# Altium schematic sheet Power.SchDoc(Power)
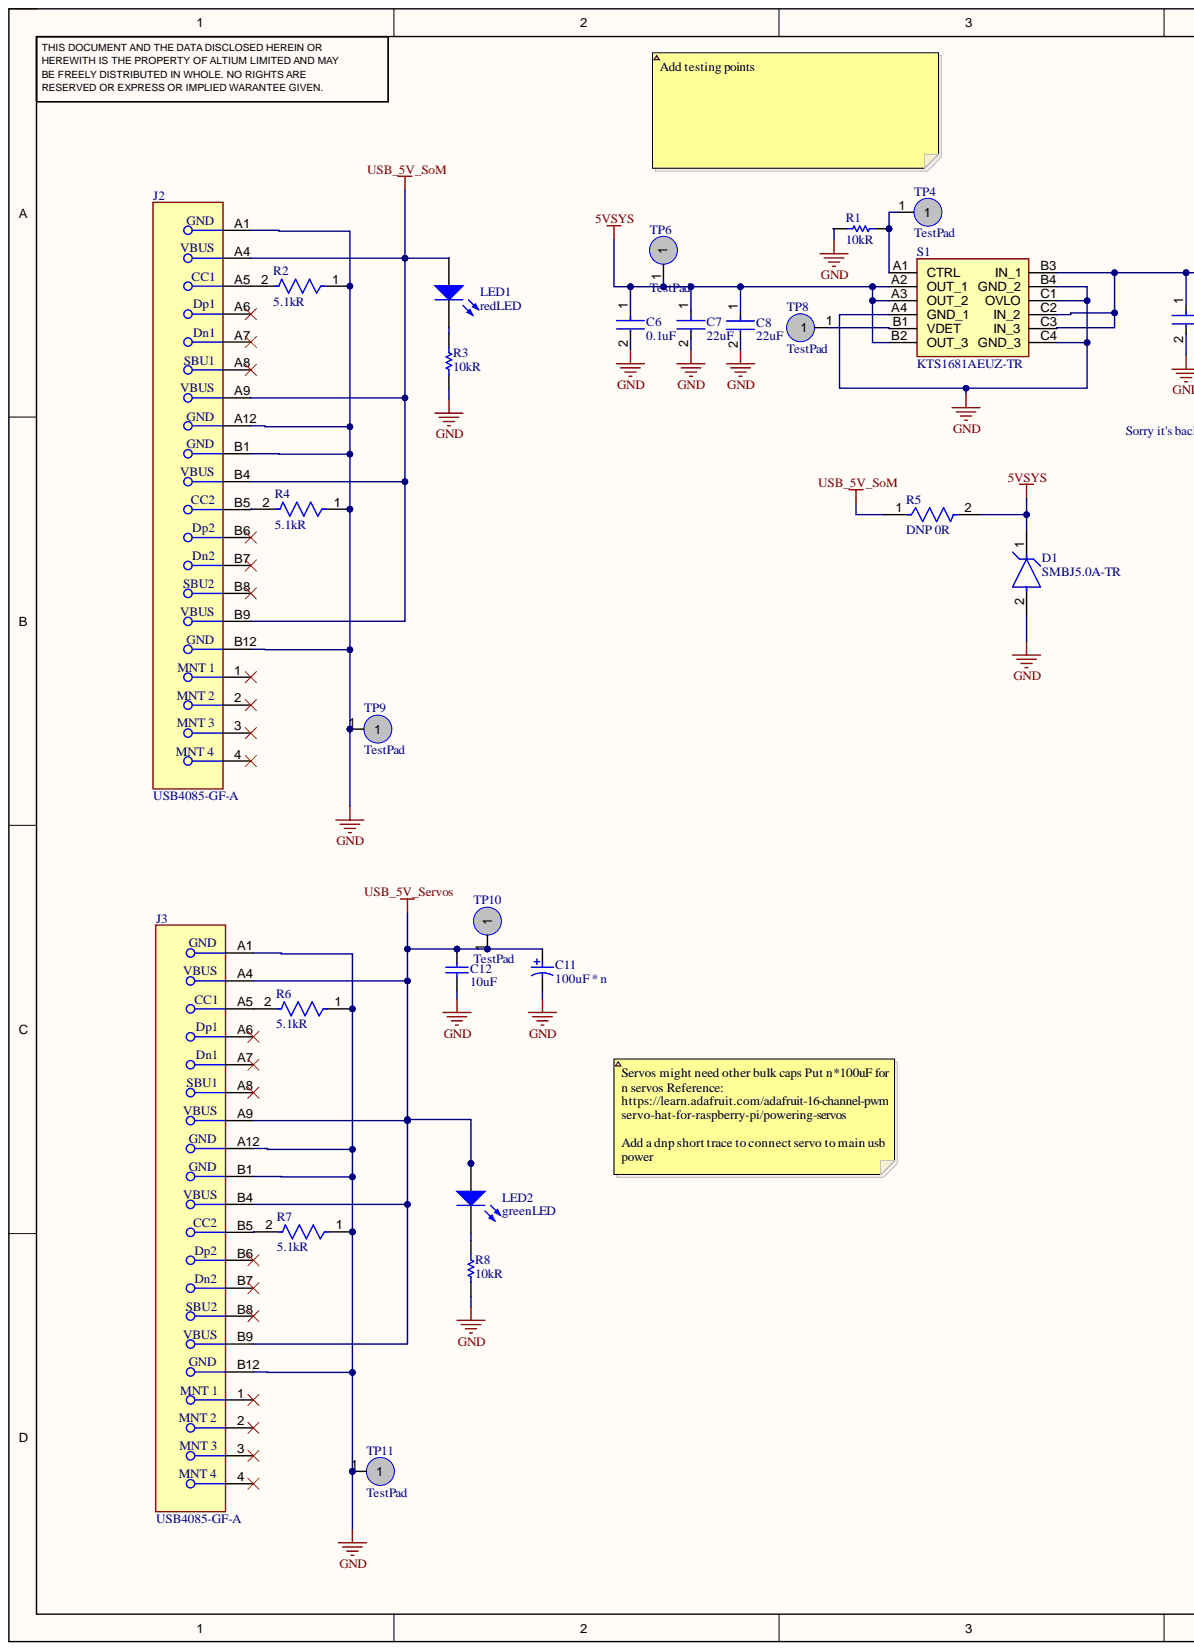
[diagram p0: Power.SchDoc, left]
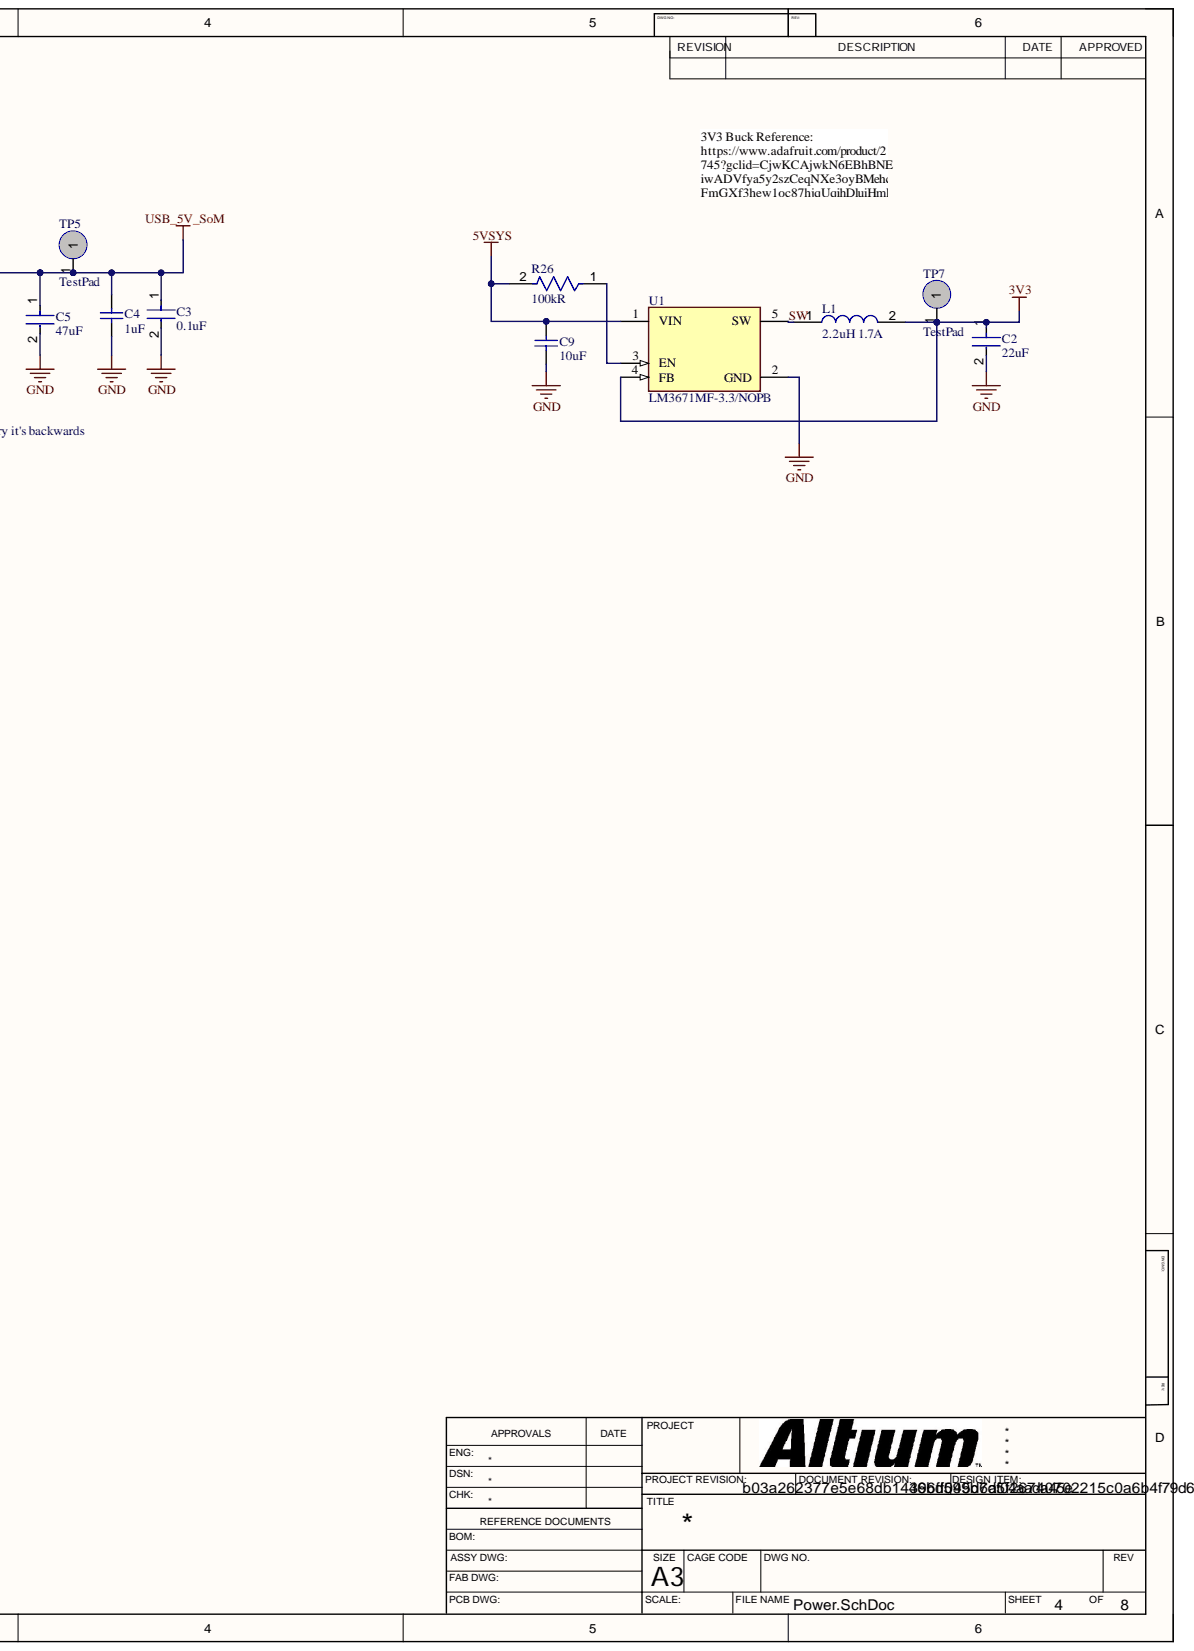
[diagram p1: Power.SchDoc, right]

## Parts
C2  22uF  [FP-GRM21B-0_15-MFG]
C3  0.1uF  [FP-C0603-CJ-MFG]
C4  1uF  [CAPC2013X145X50LL20T25]
C5  47uF  [FP-GRM31C-0_2-e0_3_0_8-IPC_C]
C6  0.1uF  [FP-C0603-CJ-MFG]
C7  22uF  [FP-GRM21B-0_15-MFG]
C8  22uF  [FP-GRM21B-0_15-MFG]
C9  10uF  [CAPC2013X145X20ML20]
C11  100uF * n  [CAPPR65-500-2000X1250X2200]
C12  10uF  [CAPC2013X145X20ML20]
D1  SMBJ5.0A-TR  [FP-SMB-MFG]
J2  USB4085-GF-A  [FP-USB4085-GF-A-MFG]
J3  USB4085-GF-A  [FP-USB4085-GF-A-MFG]
L1  2.2uH 1.7A  [NRH2412T2R2MNGH]
LED1  redLED  [0805_A]
LED2  greenLED  [0805_A]
R1  10kR  [RESC2013X60X35NL10T20]
R2  5.1kR  [FP-CRCW0805-e3-MFG]
R3  10kR  [RESC2013X60X35ML10T20]
R4  5.1kR  [FP-CRCW0805-e3-MFG]
R5  DNP 0R  [FP-RC1206-MFG]
R6  5.1kR  [FP-CRCW0805-e3-MFG]
R7  5.1kR  [FP-CRCW0805-e3-MFG]
R8  10kR  [RESC2013X60X35ML10T20]
R26  100kR  [FP-RC0603-0_55-MFG]
S1  KTS1681AEUZ-TR  [KTS1681AEUZTR]
TP4  TestPad  [TestPad50mil]
TP5  TestPad  [TestPad50mil]
TP6  TestPad  [TestPad50mil]
TP7  TestPad  [TestPad50mil]
TP8  TestPad  [TestPad50mil]
TP9  TestPad  [TestPad50mil]
TP10  TestPad  [TestPad50mil]
TP11  TestPad  [TestPad50mil]
U1  LM3671MF-3.3/NOPB  [FP-DBV0005A-IPC_C]

## Nets
3V3: C2.1 L1.2 TP7.1 U1.4(FB)
5VSYS: C6.1 C7.1 C8.1 C9.2 D1.1 R5.2 R26.2 S1.A2(OUT_1) S1.A3(OUT_2) S1.B2(OUT_3) TP6.1 U1.1(VIN)
GND: C2.2 C3.2 C4.1 C5.2 C6.2 C7.2 C8.2 C9.1 C11.2 C12.1 D1.2 J2.A1 J2.A12 J2.B1 J2.B12 J3.A1 J3.A12 J3.B1 J3.B12 R1.2 R2.1 R3.1 R4.1 R6.1 R7.1 R8.1 S1.A4(GND_1) S1.B4(GND_2) S1.C1(OVLO) S1.C4(GND_3) TP9.1 TP11.1 U1.2(GND)
USB_5V_Servos: C11.1 C12.2 J3.A4 J3.A9 J3.B4 J3.B9 LED2.2 TP10.1
USB_5V_SoM: C3.1 C4.2 C5.1 J2.A4 J2.A9 J2.B4 J2.B9 LED1.2 R5.1 S1.B3(IN_1) S1.C2(IN_2) S1.C3(IN_3) TP5.1
SW: L1.1 U1.5(SW)
NetJ2_A5: J2.A5 R2.2
NetJ2_B5: J2.B5 R4.2
NetJ3_A5: J3.A5 R6.2
NetJ3_B5: J3.B5 R7.2
NetLED1_1: LED1.1 R3.2
NetLED2_1: LED2.1 R8.2
NetR1_1: R1.1 S1.A1(CTRL) TP4.1
NetR26_1: R26.1 U1.3(EN)
NetS1_B1: S1.B1(VDET) TP8.1

Not connected: J2 1 2 3 4 A6 A7 A8 B6 B7 B8; J3 1 2 3 4 A6 A7 A8 B6 B7 B8

## Library data of the parts
C2 C7 C8 = CMP-2007-03385-2: GRM21BR60J226ME39L Chip Multilayer Ceramic Capacitors for General Purpose, 0805, 22uF, X5R, 15%, 20%, 6.3V; Capacitance: 22uF; Case Code (Imperial): 0805; Case Code (Metric): 2012; Case/Package: 0805; Component Kind: Standard; Depth: 1.25mm; Dielectric: X5R; Height: 1.25mm; Lead Free: Lead Free; Length: 2mm; Library Name: Altium Content Vault; Material: Ceramic; Max Operating Temperature: 85°C; Min Operating Temperature: -55°C; Mount: Surface Mount; Package Quantity: 1; Packaging: Tape and Reel; Radiation Hardening: No; REACH SVHC: No SVHC; Termination: SMD/SMT; Thickness: 0.053inch; Tolerance: 20%; Voltage: 6.3V; Voltage Rating: 6.3V; Voltage Rating (DC): 6.3V; Width: 0.049inch
C3 C6 = CMP-2006-03300-2: C0603X104K5RACTU CAP CER 0.1UF 50V X7R 0603; Manufacturer: Kemet; Capacitance: 100nF; Material: Ceramic; Temperature Coefficient: 15%; Tolerance: 10%; Value: 100nF; Automotive: No; Category: Cap; Component Kind: Standard; ComponentLink2Description: Datasheet; ComponentLink2URL: https://content.kemet.com/datasheets/KEM_C1013_X7R_FT-CAP_SMD.pdf; Device Class L1: Passive Components; Device Class L2: Capacitors; Device Class L3: Ceramic Capacitors; Digikey Description: CAP CER 0.1UF 50V X7R 0603; Height: 0.95mm; Ipc Land Pattern Name: CAPC16080X80; Lead Free: Yes; Library Name: Altium Content Vault; Manufacturer Part Number: C0603X104K5RACTU; Mouser Description: Capacitor; Ceramic; Cap 0.1uF; Tol+10%; SMT; Flexible Termination; Vol-Rtg 50V; TR; Octopart: https://octopart.com/c0603x104k5ractu-kemet-9145062; Package: C0603-CJ; RoHS: TRUE; Temperature: -55°C to +125°C; Temperature Characteristic: X7R; Temperature Range High: +125°C; Temperature Range Low: -55°C; Voltage: 50V; Voltage Rating: 50V
C4 = CMP-1036-04746-2: C0805C105K4PACTU; Manufacturer: Kemet; Component Kind: Standard; Library Name: Altium Content Vault; Part Number: C0805C105K4PACTU
C5 = CMP-2000-06344-2: GRM31CR60J476ME19L Chip Multilayer Ceramic Capacitors for General Purpose, 1206, 47uF, X5R, 15%, 20%, 6.3V; Capacitance: 47uF; Case Code (Imperial): 1206; Case Code (Metric): 3216; Case/Package: 1206; Component Kind: Standard; Depth: 1.6mm; Dielectric: X5R; Height: 1.6mm; Lead Free: Lead Free; Length: 3.2mm; Library Name: Altium Content Vault; Material: Ceramic; Max Operating Temperature: 85°C; Min Operating Temperature: -55°C; Mount: Surface Mount; Package Quantity: 2000; Packaging: Tape and Reel; Radiation Hardening: No; REACH SVHC: No SVHC; Termination: SMD/SMT; Thickness: 0.071inch; Tolerance: 20%; Voltage: 6.3V; Voltage Rating: 6.3V; Voltage Rating (DC): 6.3V; Width: 0.063inch
C9 C12 = CMP-2000-05452-1: C2012X7R1A106K125AC Multilayer Ceramic Chip Capacitor, 10 uF, +/- 10%, 10 V, -55 to 125 degC, 0805 (2012 Metric), RoHS, Tape and Reel; Manufacturer: TDK; PCN Part Number: MLCCPartNumberChange30/Nov/2012; Capacitance: 10µF; Online Catalog: CSeries,GeneralPurpose; Other Names: 445-6857-6; Product Training Modules: CSeriesGeneralApplicationsSEAT,CCV,andTVCLDesignToolsGuidetoReplacinganElectrolyticCapacitorwithanMLCC; Temperature Coefficient: X7R; Voltage - Rated: 10V; Applications: GeneralPurpose; Category: Capacitors; Component Kind: Standard; Datasheet URL: http://download.siliconexpert.com/pdfs/2015/4/21/15/54/45/779/tdk_/manual/37024850441143360mlcc_commercial_general_en.pdf; Datasheet Version: Mar-15; Family: CeramicCapacitors; Features: -; Height - Seated (Max): -; Lead Spacing: -; Lead Style: -; Library Name: Altium Content Vault; Manufacturer URL: http://www.tdk.com/; Mounting Type: SurfaceMount,MLCC; Operating Temperature: -55degC~125degC; Package / Case: 0805(2012Metric); Package Description: 2-Pin Surface Mount Device, Body 2 x 1.25 mm; Package Reference: 805; Packaging: Digi-Reel; Ratings: -; RoHS Information: RoHSCertificate-MLCC; Series: C; Size / Dimension: 0.079"Lx0.049"W(2.00mmx1.25mm); Standard Package: 1; Thickness (Max): 0.057"(1.45mm); Tolerance: ±10%
C11 = CMP-1665-00014-4: ECA-1JHG471 Aluminum Electrolytic Capacitor (Radial Lead Type), 470 uF, 63 V, -55 to 105 degC, 2-Pin THD, RoHS, Bulk; Manufacturer: Panasonic; Voltage Rating: 63 V; Component Kind: Standard; ComponentLink1Description: Manufacturer URL; ComponentLink1URL: http://www.panasonic.com/; ComponentLink2Description: Datasheet; ComponentLink2URL: http://industrial.panasonic.com/cdbs/www-data/pdf/RDF0000/ABA0000C1038.pdf; DatasheetVersion: 03/2016; Height: 20 mm; Lead Pitch: 5 mm; Length: 20 mm; Library Name: Altium Content Vault; Max Operating Temperature: 105 degC; Min Operating Temperature: -55 degC; Mount: Through Hole; Mounting Technology: Through Hole; Package Quantity: 200; PackageDescription: 2-Pin Through Hole Device, Dia 12.5 mm, Body Length 20 mm; PackageReference: Radial; Packaging: Bulk; Pins: 2; REACH SVHC: No SVHC; RoHSCompliant: true
D1 = CMP-12225-000019-1: TVS DIODE 5V 13.4V SMB; Manufacturer: STMicroelectronics; Breakdown Voltage: 6.4V; Clamping Voltage: 14.4V; Direction: Unidirectional; Max Junction Temp: +150°C; Number Of Channels: 1; Peak Pulse Current: 275A; Peak Pulse Power: 600W; Standoff Height: 0.05mm; Automotive: No; Category: Diode; Component Kind: Standard; ComponentLink2Description: Datasheet; ComponentLink2URL: https://www.st.com/resource/en/datasheet/smbj.pdf; Device Class L1: Circuit Protection; Device Class L2: TVS Diodes; Device Class L3: unset; Digikey Description: TVS DIODE 5V 13.4V SMB; Height: 2.65mm; Lead Free: Yes; Library Name: Altium Content Vault; Manufacturer Part Number: SMBJ5.0A-TR; Mouser Description: SMBJ Series 600 W 5 V Uni Directional SMT TVS Diode - DO-214AA; Octopart: https://octopart.com/smbj5.0a-tr-stmicroelectronics-347079; Package: SMB; Reverse Standoff Voltage: 5V; RoHS: TRUE; Temperature: -55°C to +150°C; Temperature Range High: +150°C; Temperature Range Low: -55°C
J2 J3 = CMP-196119-000001-1: CONN RCPT USB2.0 TYPEC 16POS; Manufacturer: Global Connector Technology; Conn Orientation: Right Angle; Current Rating: 4.25A; Is Female: yes; Number Of Contacts: 16; Pitch: 0.85mm; Shielding: true; Voltage Rating: 20V; Automotive: No; Category: Conn; Component Kind: Standard; ComponentLink2Description: Datasheet; ComponentLink2URL: https://gct.co/files/drawings/usb4085.pdf; Contact Material: Gold,Nickel; Device Class L1: Connectors; Device Class L2: USB Connectors; Device Class L3: unset; Digikey Description: CONN RCPT USB2.0 TYPEC 16POS; Height: 3.66mm; Lead Free: Yes; Library Name: Altium Content Vault; Manufacturer Part Number: USB4085-GF-A; Octopart: https://octopart.com/usb4085-gf-a-global+connector+technology-94773699; Package: 0; RoHS: TRUE; Temperature: -40°C to +85°C; Temperature Range High: +85°C; Temperature Range Low: -40°C; Usb Standard: USB 2.0
L1 = NRH2412T2R2MNGH: Inductor NRH2412T2R2MNGH; Manufacturer_Name: TAIYO YUDEN; Arrow Part Number: NRH2412T2R2MNGH; Arrow Price/Stock: https://www.arrow.com/en/products/nrh2412t2r2mngh/taiyo-yuden; Component Kind: Standard; Datasheet Link: http://ds.yuden.co.jp/TYCOMPAS/ut/detail.do?productNo=NRH2412T2R2MNGH&fileName=NRH2412T2R2MNGH_SS&mode=specSheetDownload; Height: 1mm; Manufacturer_Part_Number: NRH2412T2R2MNGH; Mouser Part Number: 963-NRH2412T2R2MNGH; Mouser Price/Stock: https://www.mouser.co.uk/ProductDetail/Taiyo-Yuden/NRH2412T2R2MNGH?qs=CNQs48zzdnqQ4uJGFCRMww%3D%3D
LED1 = CMP-1426-00010-1: SMD mono-color Chip LED, WL-SMCW, Super Red; Manufacturer: Wuerth Elektronik; PartNumber: 150080SS75000; Color: Super Red; Component Kind: Standard; ComponentLink1Description: Manufacturer URL; ComponentLink1URL: http://www.we-online.com; ComponentLink2Description: Datasheet; ComponentLink2URL: http://katalog.we-online.com/led/datasheet/150080SS75000.pdf; Library Name: Altium Content Vault; PackageReference: 0805_A
LED2 = CMP-1426-00007-1: SMD mono-color Chip LED, WL-SMCW, Green; Manufacturer: Wuerth Elektronik; PartNumber: 150080GS75000; Color: Green; Component Kind: Standard; ComponentLink1Description: Manufacturer URL; ComponentLink1URL: http://www.we-online.com; ComponentLink2Description: Datasheet; ComponentLink2URL: http://katalog.we-online.com/led/datasheet/150080GS75000.pdf; Library Name: Altium Content Vault; PackageReference: 0805_A
R1 = CMP-1659-00013-4: RC0805FR-0710KL Chip Resistor, 10 KOhm, +/- 1%, 0.125 W, -55 to 155 degC, 0805 (2012 Metric), RoHS, Tape and Reel; Manufacturer: Yageo; Voltage Rating: 150 V; Component Kind: Standard; ComponentLink1Description: Manufacturer URL; ComponentLink1URL: http://www.yageo.com/; ComponentLink2Description: Datasheet; ComponentLink2URL: http://www.yageo.com/documents/recent/PYu-RC_Group_51_RoHS_L_04.pdf; DatasheetVersion: Rev. 4, 02/2016; Height: 0.5 mm; Length: 2 mm; Library Name: Altium Content Vault; Max Operating Temperature: 155 degC; Min Operating Temperature: -55 degC; Mount: Surface Mount; Mounting Technology: Surface Mount; Package Quantity: 5000; PackageDescription: 2-Pin Surface Mounted Device, Body 2 x 1.25 mm; PackageReference: 0805; Packaging: Tape and Reel; Pins: 2; REACH SVHC: No SVHC; RoHSCompliant: true
R2 R4 R6 R7 = CMP-2001-04024-2: RES Thick Film, 5.1kΩ, 1%, 0.125W, 100ppm/°C, 0805 CRCW08055K10FKEA; Max Power Dissipation: 125mW; Voltage Rating: 150V; Case Code (Imperial): 0805; Case Code (Metric): 2012; Case/Package: 0805; Component Kind: Standard; Composition: Thick Film; Contact Plating: Tin; Depth: 1.25mm; Features: Automotive AEC-Q200; Height: 0.45mm; Lead Free: Lead Free; Length: 2mm; Library Name: Altium Content Vault; Max Operating Temperature: 155°C; Military Standard: Not; Min Operating Temperature: -55°C; Mount: Surface Mount; Number of Terminations: 2; Package Quantity: 10; Packaging: Tape and Reel; Power Rating: 125mW; Radiation Hardening: No; Resistance: 5.1kR; Temperature Coefficient: 100ppm/°C; Termination: SMD/SMT; Tolerance: 1%; Voltage Rating (DC): 150V; Weight: 0.000194oz; Width: 0.049inch
R3 R8 = CMP-2100-03671-1: RC0805FR-071KL Chip Resistor, 1 KOhm, +/- 1%, 125 mW, -55 to 155 degC, 0805 (2012 Metric), RoHS, Tape and Reel; Manufacturer: Yageo; Max Power Dissipation: 125mW; Resistance: 1KOhm; Temperature Coefficient: 100ppm/degreesC; Voltage Rating: 150V; Case Code (Imperial): 0805; Case Code (Metric): 2012; Case/Package: 0805; Component Kind: Standard; Composition: ThickFilm; Datasheet URL: http://www.yageo.com/NewPortal/yageodocoutput?fileName=/pdf/R-Chip/PYu-RC_Group_51_RoHS_L_5.pdf; Datasheet Version: Rev. 5, 10/2016; Depth: 1.25mm; Height: 0.024inch; Lead Free: LeadFree; Length: 0.079inch; Library Name: Altium Content Vault; Manufacturer URL: http://www.yageo.com/; Max Operating Temperature: 155degC; Military Standard: Not; Min Operating Temperature: -55degC; Number of Pins: 2; Number of Terminations: 2; Package Description: 2-Pin Surface Mounted Device, Body 2 x 1.25 mm; Package Quantity: 1; Package Reference: 0805; Packaging: TapeandReel; Power Rating: 125mW; REACH SVHC: Unknown; RoHSCompliant: true; Termination: SMD/SMT; Tolerance: 1%; Voltage Rating (DC): 150V; Width: 0.049inch
R5 = CMP-2100-03681-2: RC1206JR-070RL RES SMD 0 OHM JUMPER 1/4W 1206; Manufacturer: YAGEO; Power Rating: 0.25W; Resistance: 0Ω; Temperature Coefficient: 300ppm/°C; Tolerance: 5%; Value: 0Ω; Voltage Rating: 200V; Automotive: No; Category: Res; Component Kind: Standard; ComponentLink2Description: Datasheet; ComponentLink2URL: https://www.yageo.com/upload/media/product/productsearch/datasheet/rchip/PYu-RC_Group_51_RoHS_L_10.pdf; Composition: Thick Film; Device Class L1: Passive Components; Device Class L2: Resistors; Device Class L3: Chip SMD Resistors; Digikey Description: RES SMD 0 OHM JUMPER 1/4W 1206; Footprint Url: https://www.yageo.com/upload/website/yageo_PYu-R_Mount_10_19050818_343.pdf; Height: 0.65mm; Ipc Land Pattern Name: RESC310160X55; Lead Free: Yes; Library Name: Altium Content Vault; Manufacturer Part Number: RC1206JR-070RL; Mouser Description: Resistor: thick film; SMD; 1206; 0O; 0.25W; ±5%; -55÷155°C; Octopart: https://octopart.com/rc1206jr-070rl-yageo-40025601; Package: RC1206; RoHS: TRUE; Series: RC; Temperature: -55°C to +155°C; Temperature Range High: +155°C; Temperature Range Low: -55°C
R26 = CMP-2100-03662-2: RC0603FR-07100KL RES SMD 100K OHM 1% 1/10W 0603; Max Power Dissipation: 100mW; Temperature Coefficient: 100ppm/°C; Voltage Rating: 50V; Working Voltage: 75V; Case Code (Imperial): 0603; Case Code (Metric): 1608; Case/Package: 0603; Component Kind: Standard; Construction: Rectangular; Depth: 0.8mm; Features: Moisture Resistant; Height: 0.45mm; Lead Free: Lead Free; Length: 1.6mm; Library Name: Altium Content Vault; Max Operating Temperature: 155°C; Military Standard: Not; Min Operating Temperature: -55°C; Number of Pins: 2; Number of Terminations: 2; Packaging: Tape and Reel; Power Rating: 100mW; REACH SVHC: No SVHC; Resistance: 100kR; Resistance Tolerance: 1%; Resistor Type: Thick Film; RoHS Compliant: Yes; Series: RC; Technology: THICK FILM; Termination: SMD/SMT; Tolerance: 1%; Voltage Rating (DC): 50V; Width: 0.8mm
S1 = KTS1681AEUZ-TR: Switch; Manufacturer_Name: Kinetic Technologies; Component Kind: Standard; Height: 0.665mm; Manufacturer_Part_Number: KTS1681AEUZ-TR
U1 = CMP-0063-01132-4: IC REG BUCK 3.3V 600MA SOT23-5; Frequency: 2MHz; Max Input Voltage: 5.5V; Min Input Voltage: 2.7V; Min Output Voltage: 1.1V; Number of Outputs: 1; Output Type: Fixed; Quiescent Current: 16uA; Switching Frequency: 2.6MHz; Case/Package: SOT-23; Component Kind: Standard; Contact Plating: Tin; Height: 1.02mm; Lead Free: Lead Free; Length: 2.92mm; Library Name: Altium Content Vault; Max Operating Temperature: 85°C; Max Output Current: 600mA; Max Output Voltage: 3.3V; Max Supply Voltage: 5.5V; Min Operating Temperature: -40°C; Min Supply Voltage: 2.7V; Mount: Surface Mount; Nominal Output Voltage: 3.3V; Number of Pins: 5; Output Current: 600mA; Output Voltage: 3.3V; Package Quantity: 1000; Packaging: Tape and Reel; REACH SVHC: No SVHC; RoHS Compliant: Yes; Termination: SMD/SMT; Width: 1.6mm
TP4-TP11 = TestPad: Component Kind: Standard; Library Name: SchemSymbolsGoogle.SchLib
